# Altium schematic sheet POWER.SchDoc
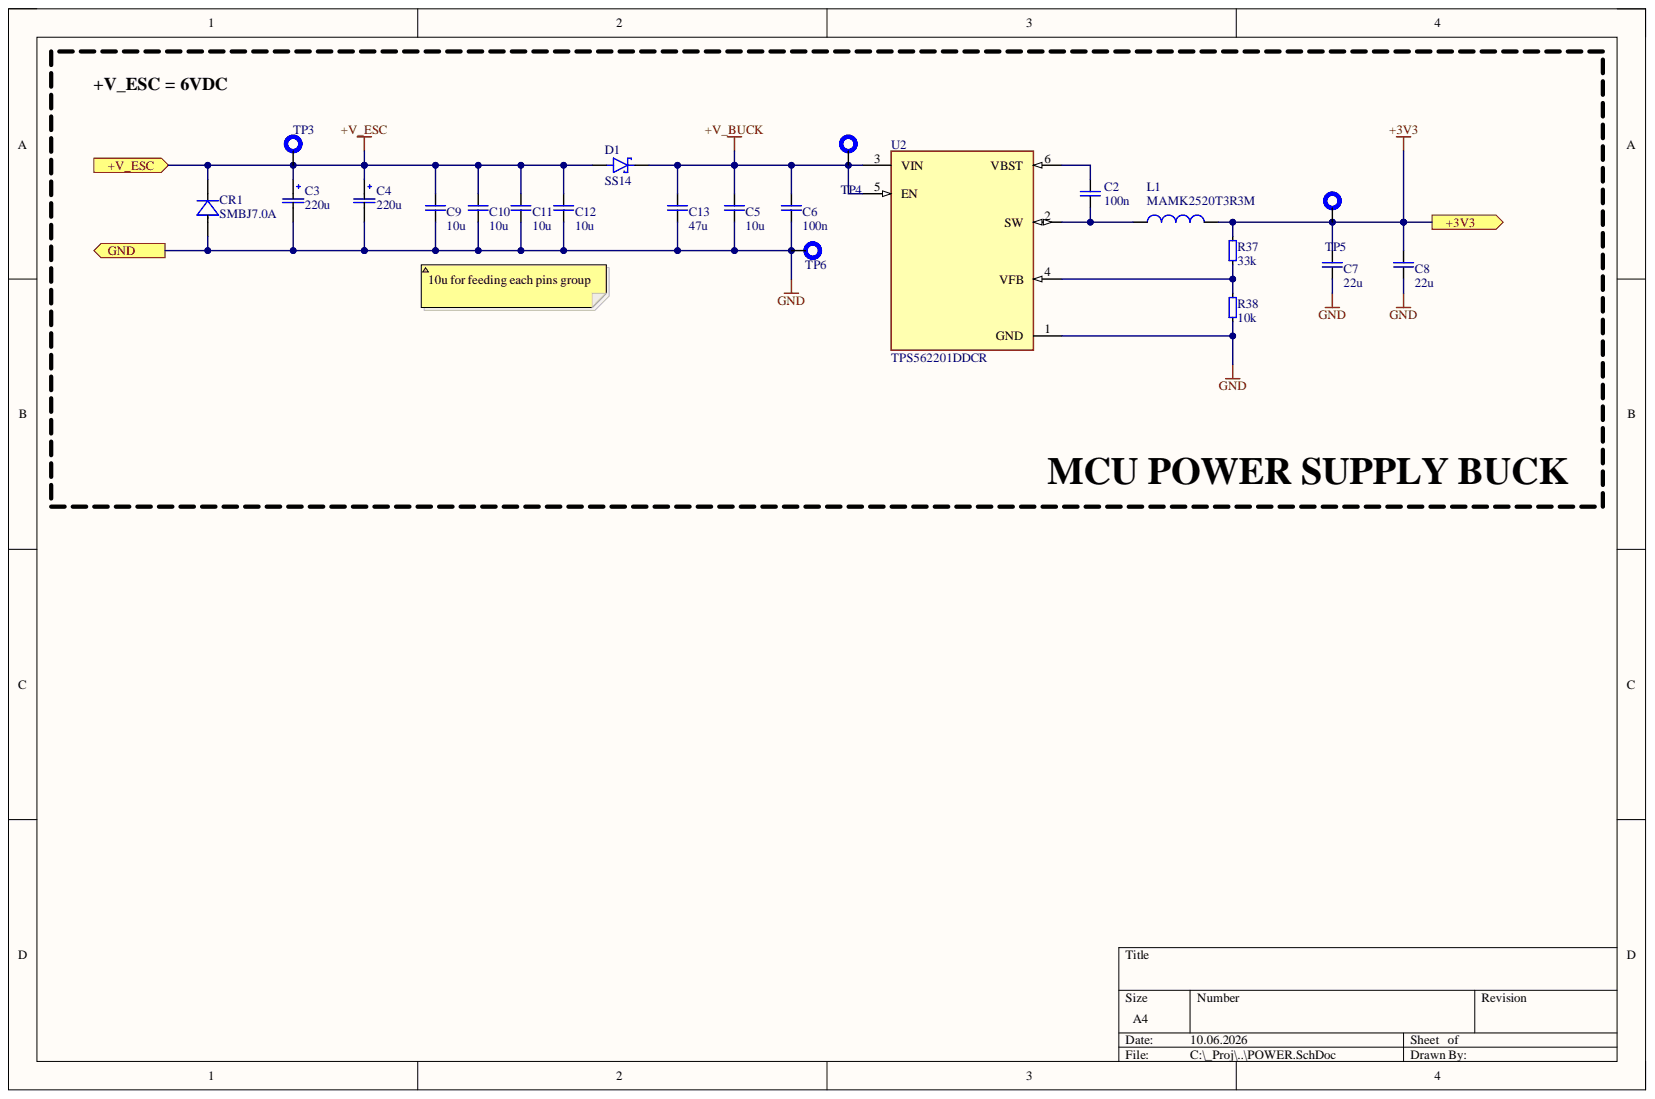
[diagram p0: POWER.SchDoc]

## Parts
C2  100n  [C0402]
C3  220u  [CAP_PC_8X10_NCH]
C4  220u  [CAP_PC_8X10_NCH]
C5  10u  [C0603]
C6  100n  [C0603]
C7  22u  [C0603]
C8  22u  [C0603]
C9  10u  [C0805]
C10  10u  [C0805]
C11  10u  [C0805]
C12  10u  [C0805]
C13  47u  [C1210]
CR1  SMBJ7.0A  [SMBJSeries_LTF]
D1  SS14  [DIOM4325X250N]
L1  MAMK2520T3R3M  [INDC2520X120N]
R37  33k  [R0402]
R38  10k  [R0402]
TP3  TP  [TP]  (no BOM)
TP4  TP  [TP]  (no BOM)
TP5  TP  [TP]  (no BOM)
TP6  TP  [TP]  (no BOM)
U2  TPS562201DDCR  [SOT95P280X110-6N]

## Ports
+3V3, +V_ESC, GND

## Nets
+V_BUCK: C5.2 C6.2 C13.2 D1.C U2.3(VIN) U2.5(EN)
+3V3: C7.2 C8.2 L1.2 R37.2 ; port +3V3
+V_ESC: C3.1 C4.1 C9.2 C10.2 C11.2 C12.2 CR1.1 D1.A ; port +V_ESC
GND: C3.2 C4.2 C5.1 C6.1 C7.1 C8.1 C9.1 C10.1 C11.1 C12.1 C13.1 CR1.2 R38.1 U2.1(GND) ; port GND
NetC2_1: C2.1 L1.1 U2.2(SW)
NetC2_2: C2.2 U2.6(VBST)
NetR37_1: R37.1 R38.2 U2.4(VFB)
NetTP3_1: TP3.1
NetTP4_1: TP4.1
NetTP5_1: TP5.1
NetTP6_1: TP6.1

## Library data of the parts
C2 = CMP-00023-15: Generic SMD 0402 Ceramic Capacitor; Component Kind: Standard; Library Name: PolSl Racing - Electrical
C3 C4 = PCJ1C221MCL4GS: No Description Available; Manufacturer_Name: Nichicon; Component Kind: Standard; Manufacturer_Part_Number: PCJ1C221MCL4GS; Copyright: Copyright (C) 2026 Ultra Librarian. All rights reserved.; Library Name: Tractor2000.SchLib
C5-C8 = CMP-00024-8: Generic 0603 SMD Ceramic Capacitor; Component Kind: Standard; Library Name: PolSl Racing - Electrical
C9-C12 = CMP-00025-5: Generic 0805 SMD Ceramic Capacitor; Component Kind: Standard; Library Name: PolSl Racing - Electrical
C13 = CMP-00027-6: Generic 1210 SMD Ceramic Capacitor; Component Kind: Standard; Library Name: PolSl Racing - Electrical
CR1 = SMBJ7.0A: Description; Manufacturer_Name: Littelfuse Inc; Component Kind: Standard; Manufacturer_Part_Number: SMBJ7.0A; Copyright: Copyright (C) 2025 Ultra Librarian. All rights reserved.; Library Name: Tractor2000.SchLib
D1 = SS14: 1A, 40V, Schottky Rectifier; MF: Taiwan Semiconductor; Check_prices: https://www.snapeda.com/parts/SS14/taiwan/view-part/?ref=eda; Component Kind: Standard; MP: SS14; Package: SMA-2 Taiwan Semiconductor; SnapEDA_Link: https://www.snapeda.com/parts/SS14/taiwan/view-part/?ref=snap; Library Name: Tractor2000.SchLib
L1 = MAMK2520T3R3M: 3.3 ÂµH Shielded Drum Core, Wirewound Inductor 1.7 A 156mOhm Max 1008 (2520 Metric); MF: Taiyo Yuden; Check_prices: https://www.snapeda.com/parts/MAMK2520T3R3M/Taiyo+Yuden/view-part/?ref=eda; Component Kind: Standard; MP: MAMK2520T3R3M; Package: 2520 Taiyo Yuden; SnapEDA_Link: https://www.snapeda.com/parts/MAMK2520T3R3M/Taiyo+Yuden/view-part/?ref=snap; Library Name: Tractor2000.SchLib
R37 R38 = CMP-00016-11: Generic 0402 SMD Resistor; Component Kind: Standard; Library Name: PolSl Racing - Electrical
U2 = TPS562201DDCR: 4.5 V to 17 V input, 2 A output, synchronous step-down converter in Eco-mode; MF: Texas Instruments; Check_prices: https://www.snapeda.com/parts/TPS562201DDCR/Texas+Instruments/view-part/?ref=eda; Component Kind: Standard; MP: TPS562201DDCR; Package: SOT-23-THN-6 Texas Instruments; SnapEDA_Link: https://www.snapeda.com/parts/TPS562201DDCR/Texas+Instruments/view-part/?ref=snap; Library Name: Tractor2000.SchLib
TP3-TP6 = TP: Library Name: Tractor2000.SchLib
